# Altium schematic sheet MainBoard.SchDoc(MainBoard)
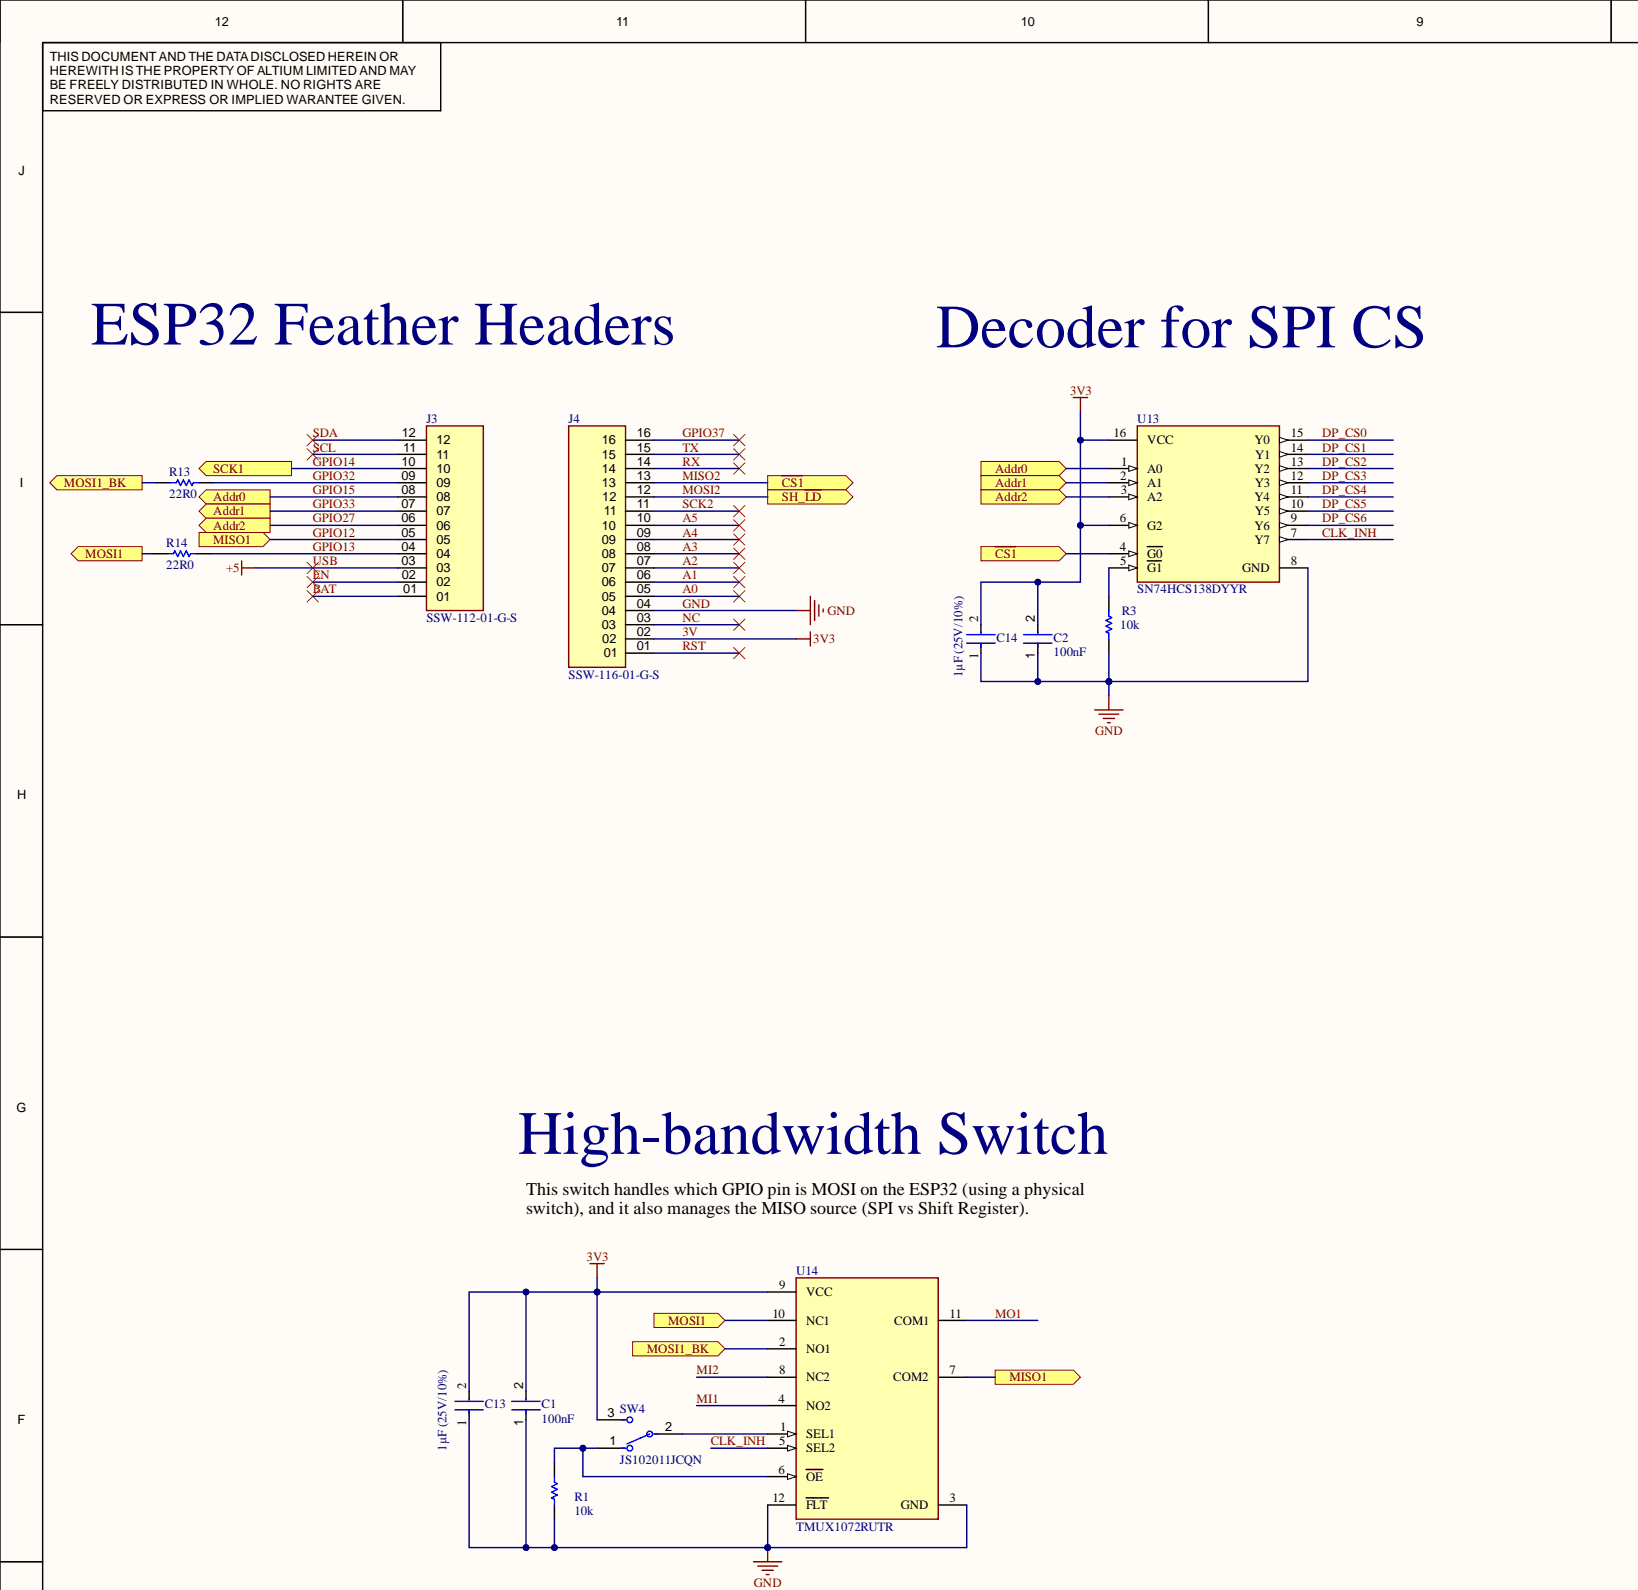
[diagram p0: MainBoard.SchDoc, top left]
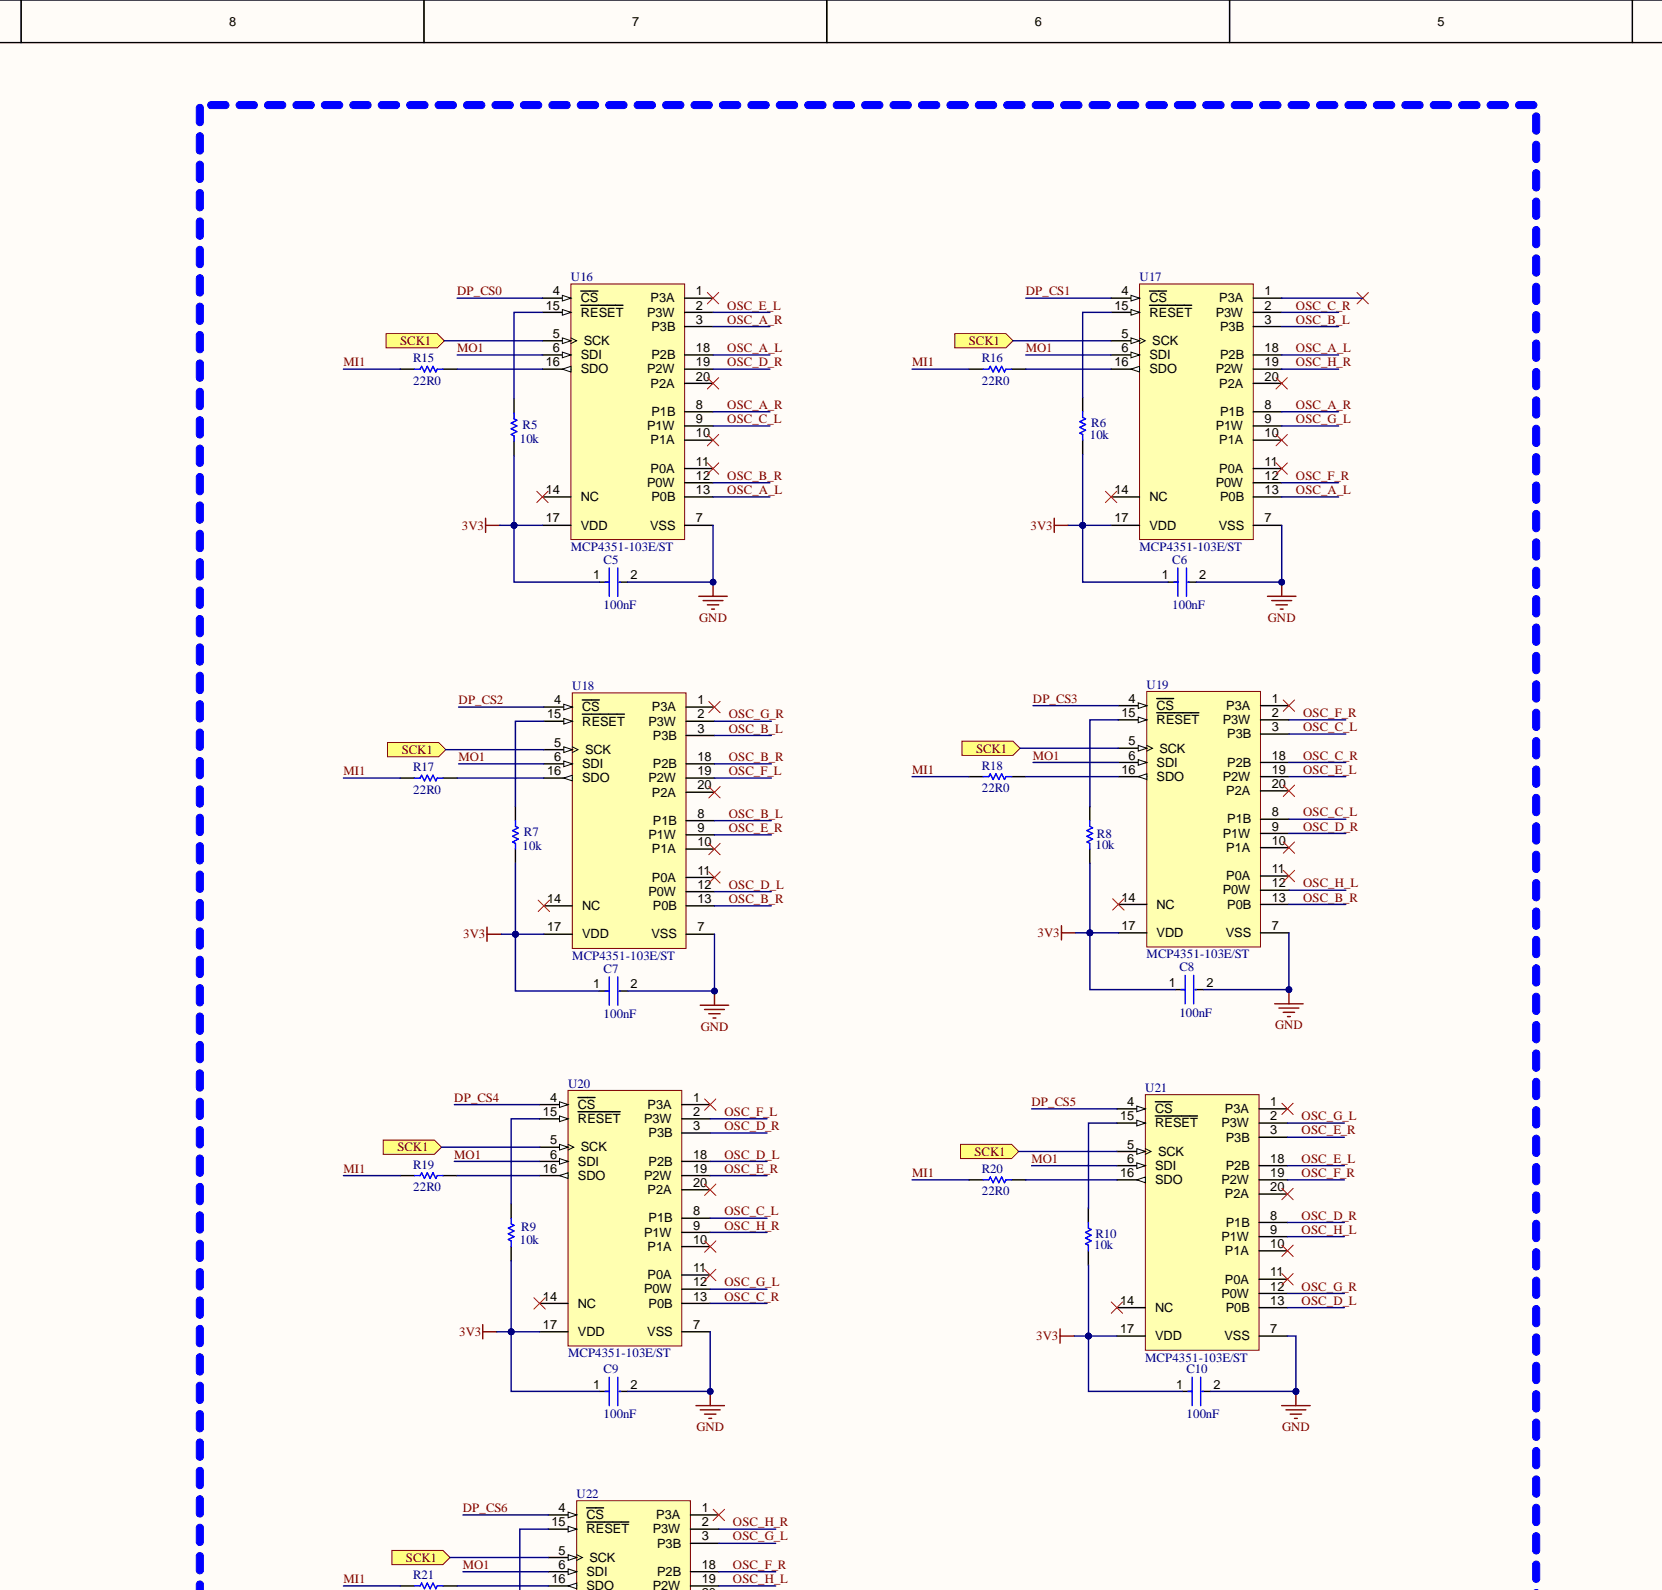
[diagram p1: MainBoard.SchDoc, top centre]
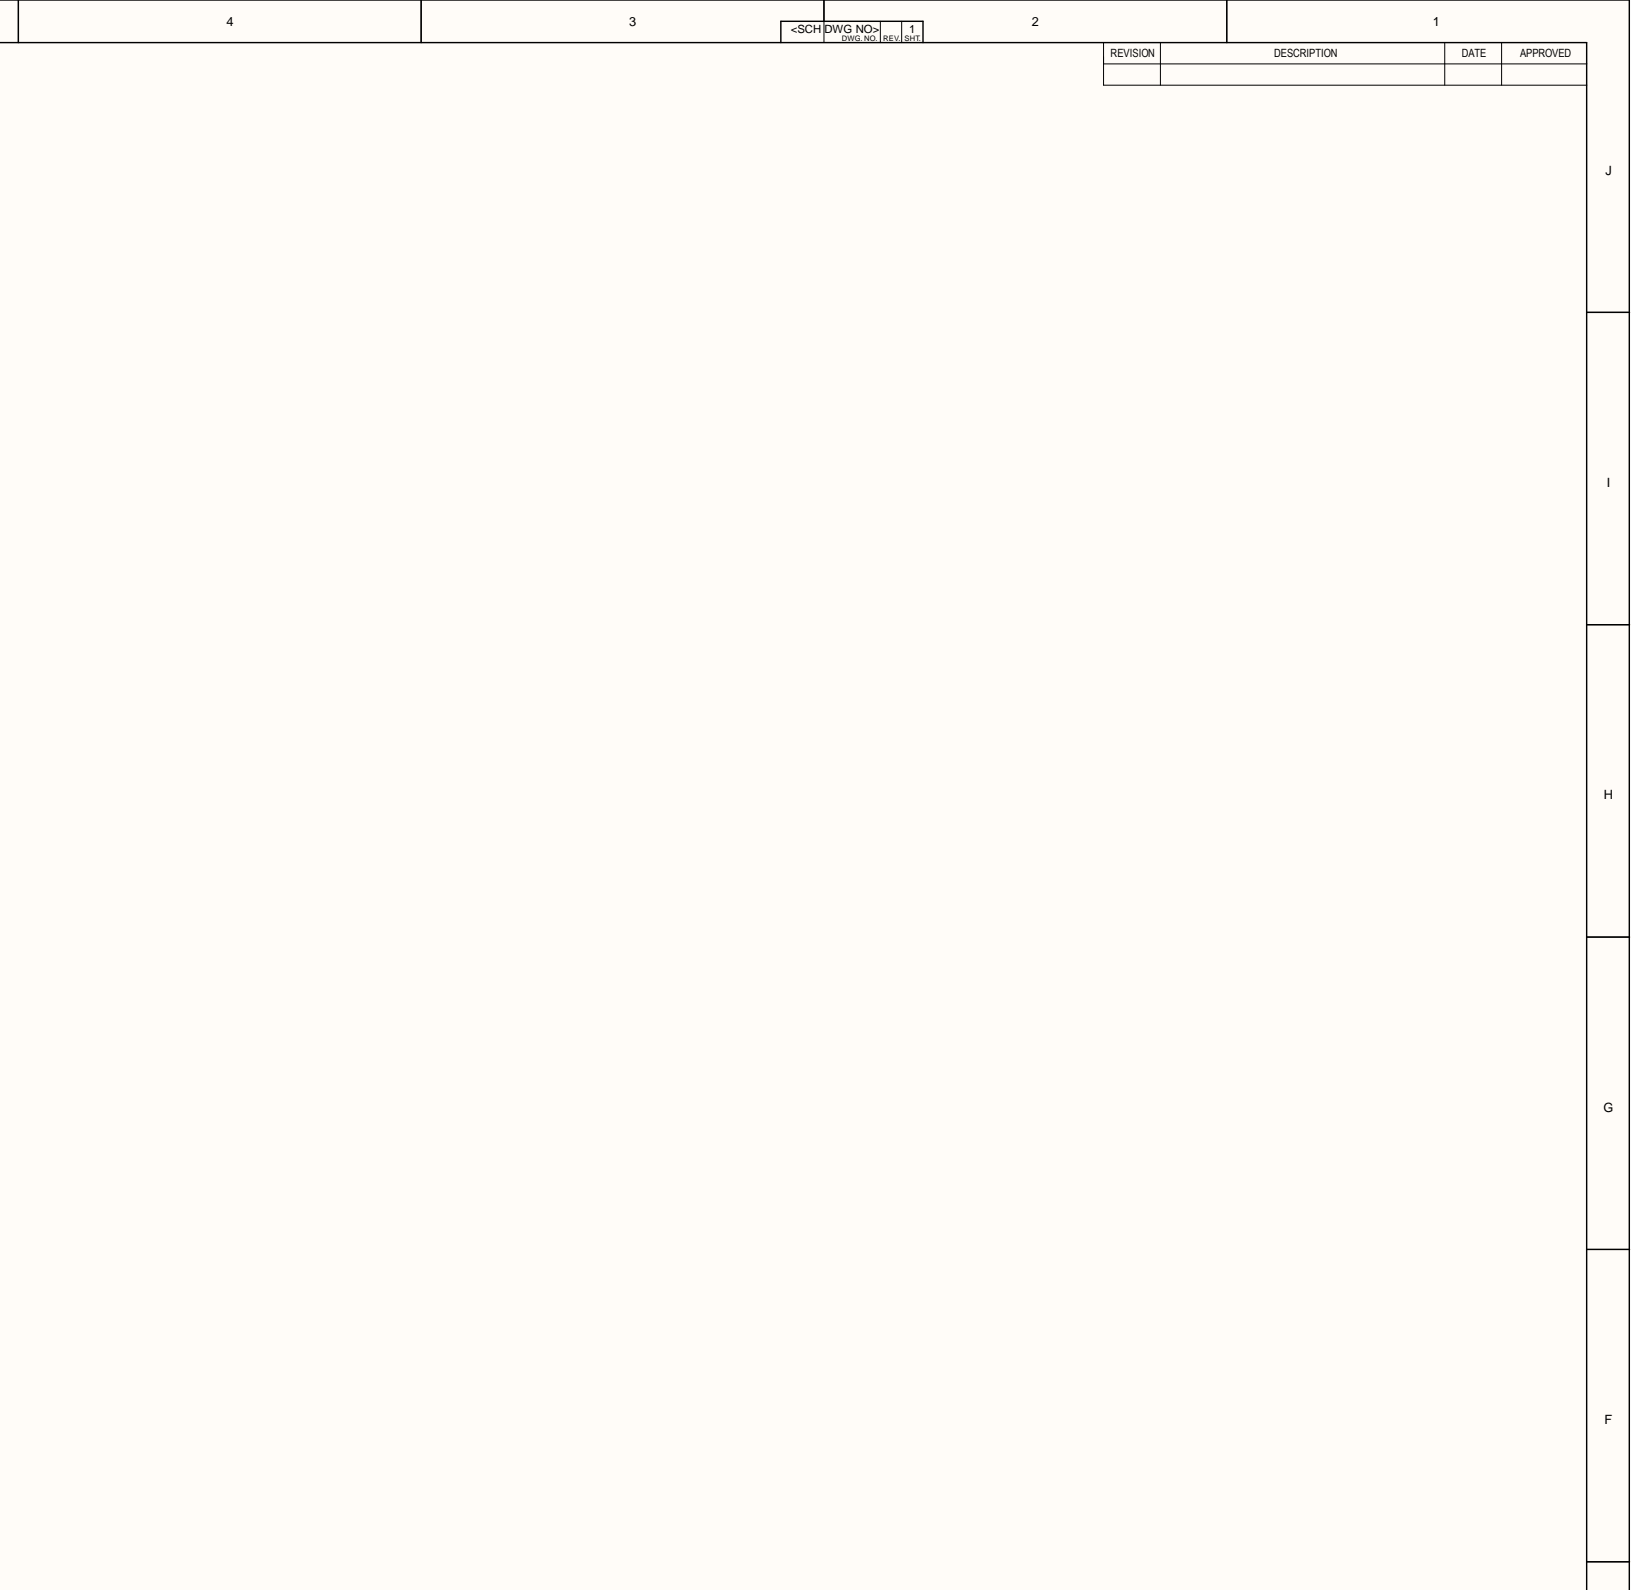
[diagram p2: MainBoard.SchDoc, top right]
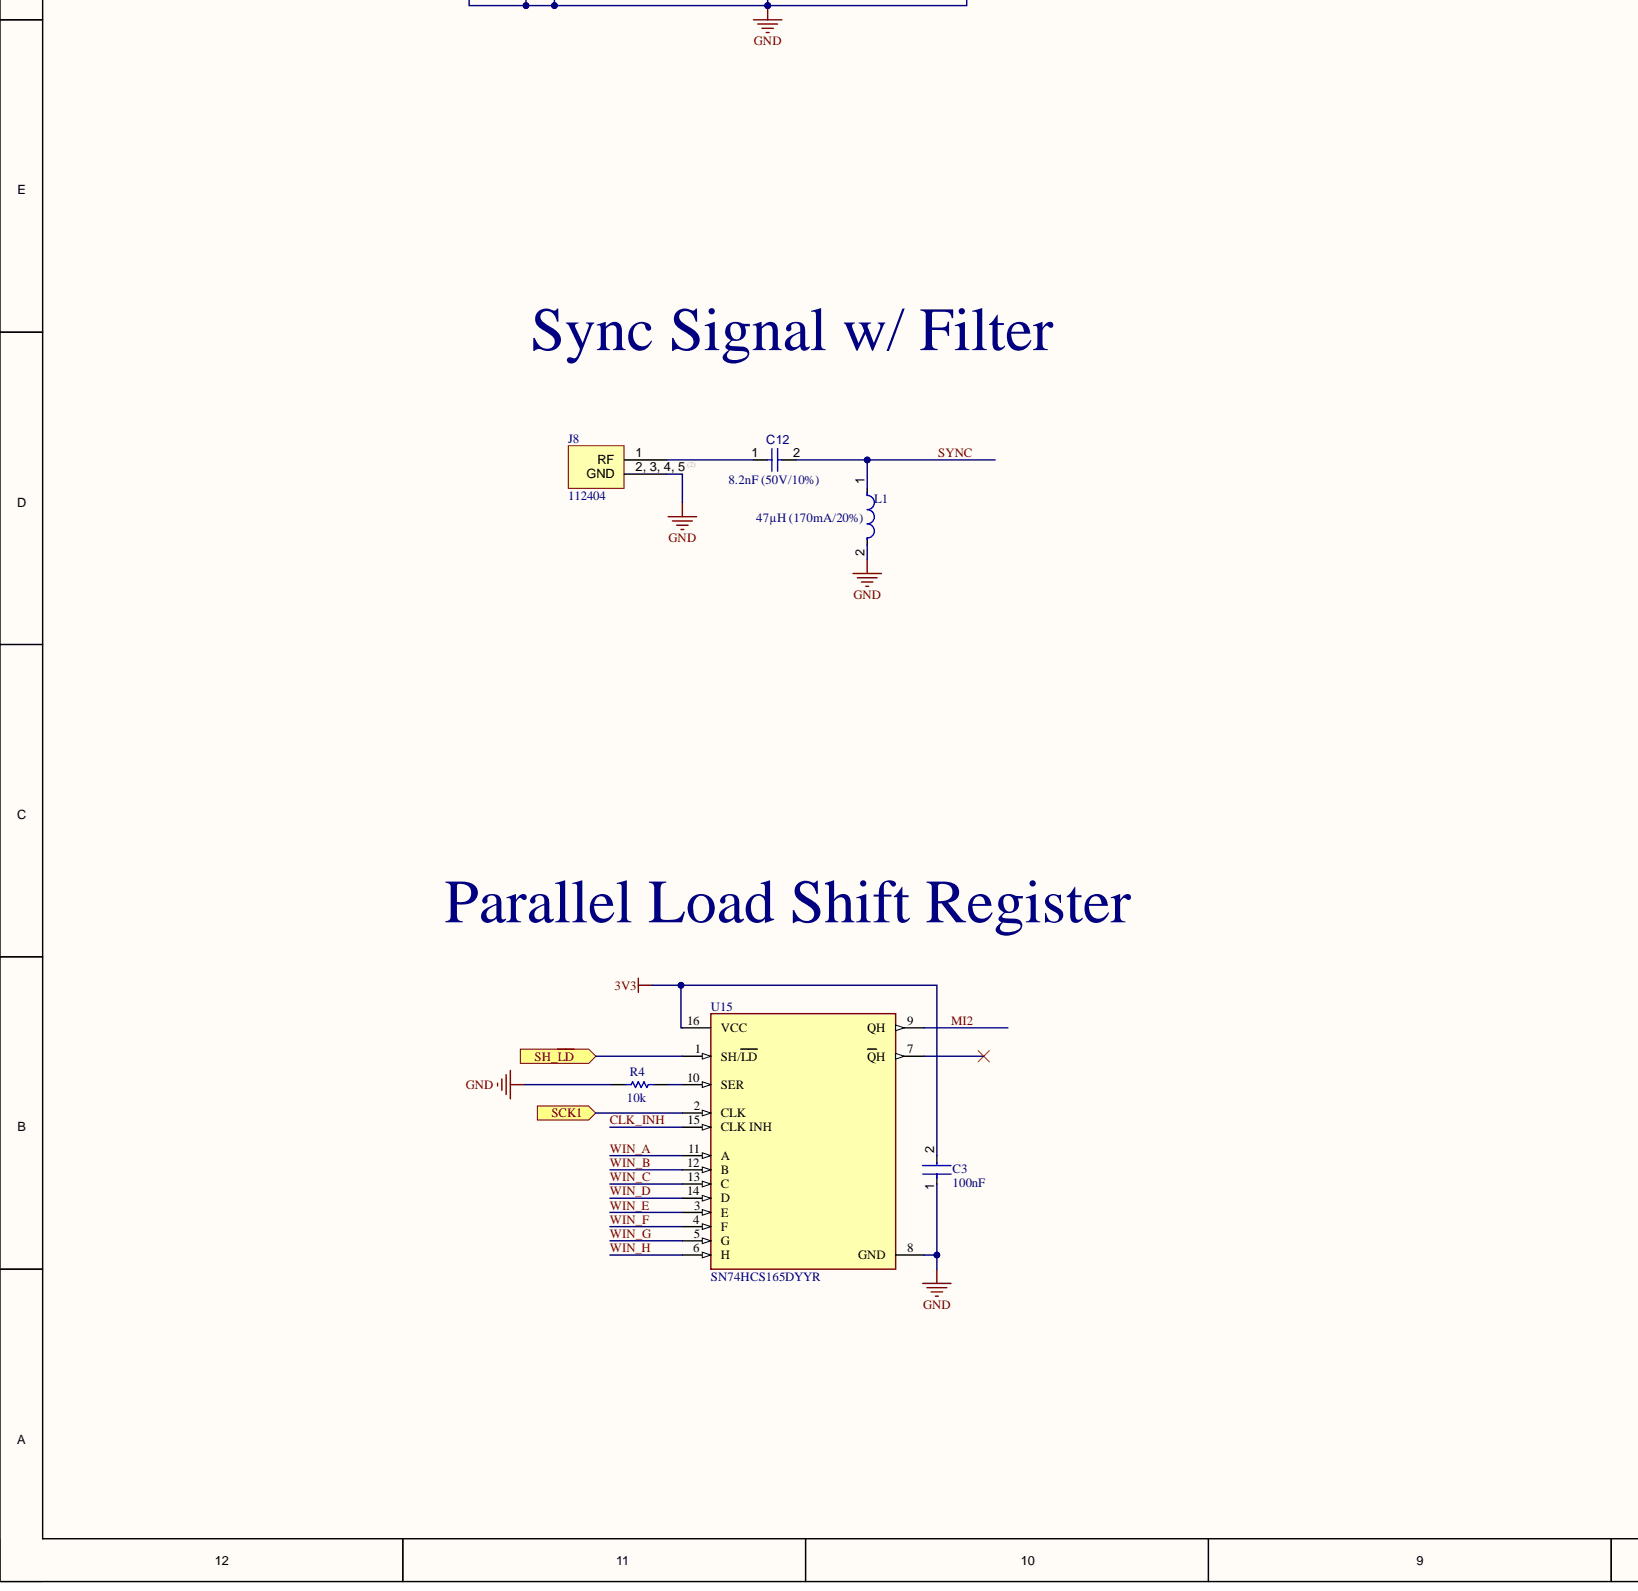
[diagram p3: MainBoard.SchDoc, bottom left]
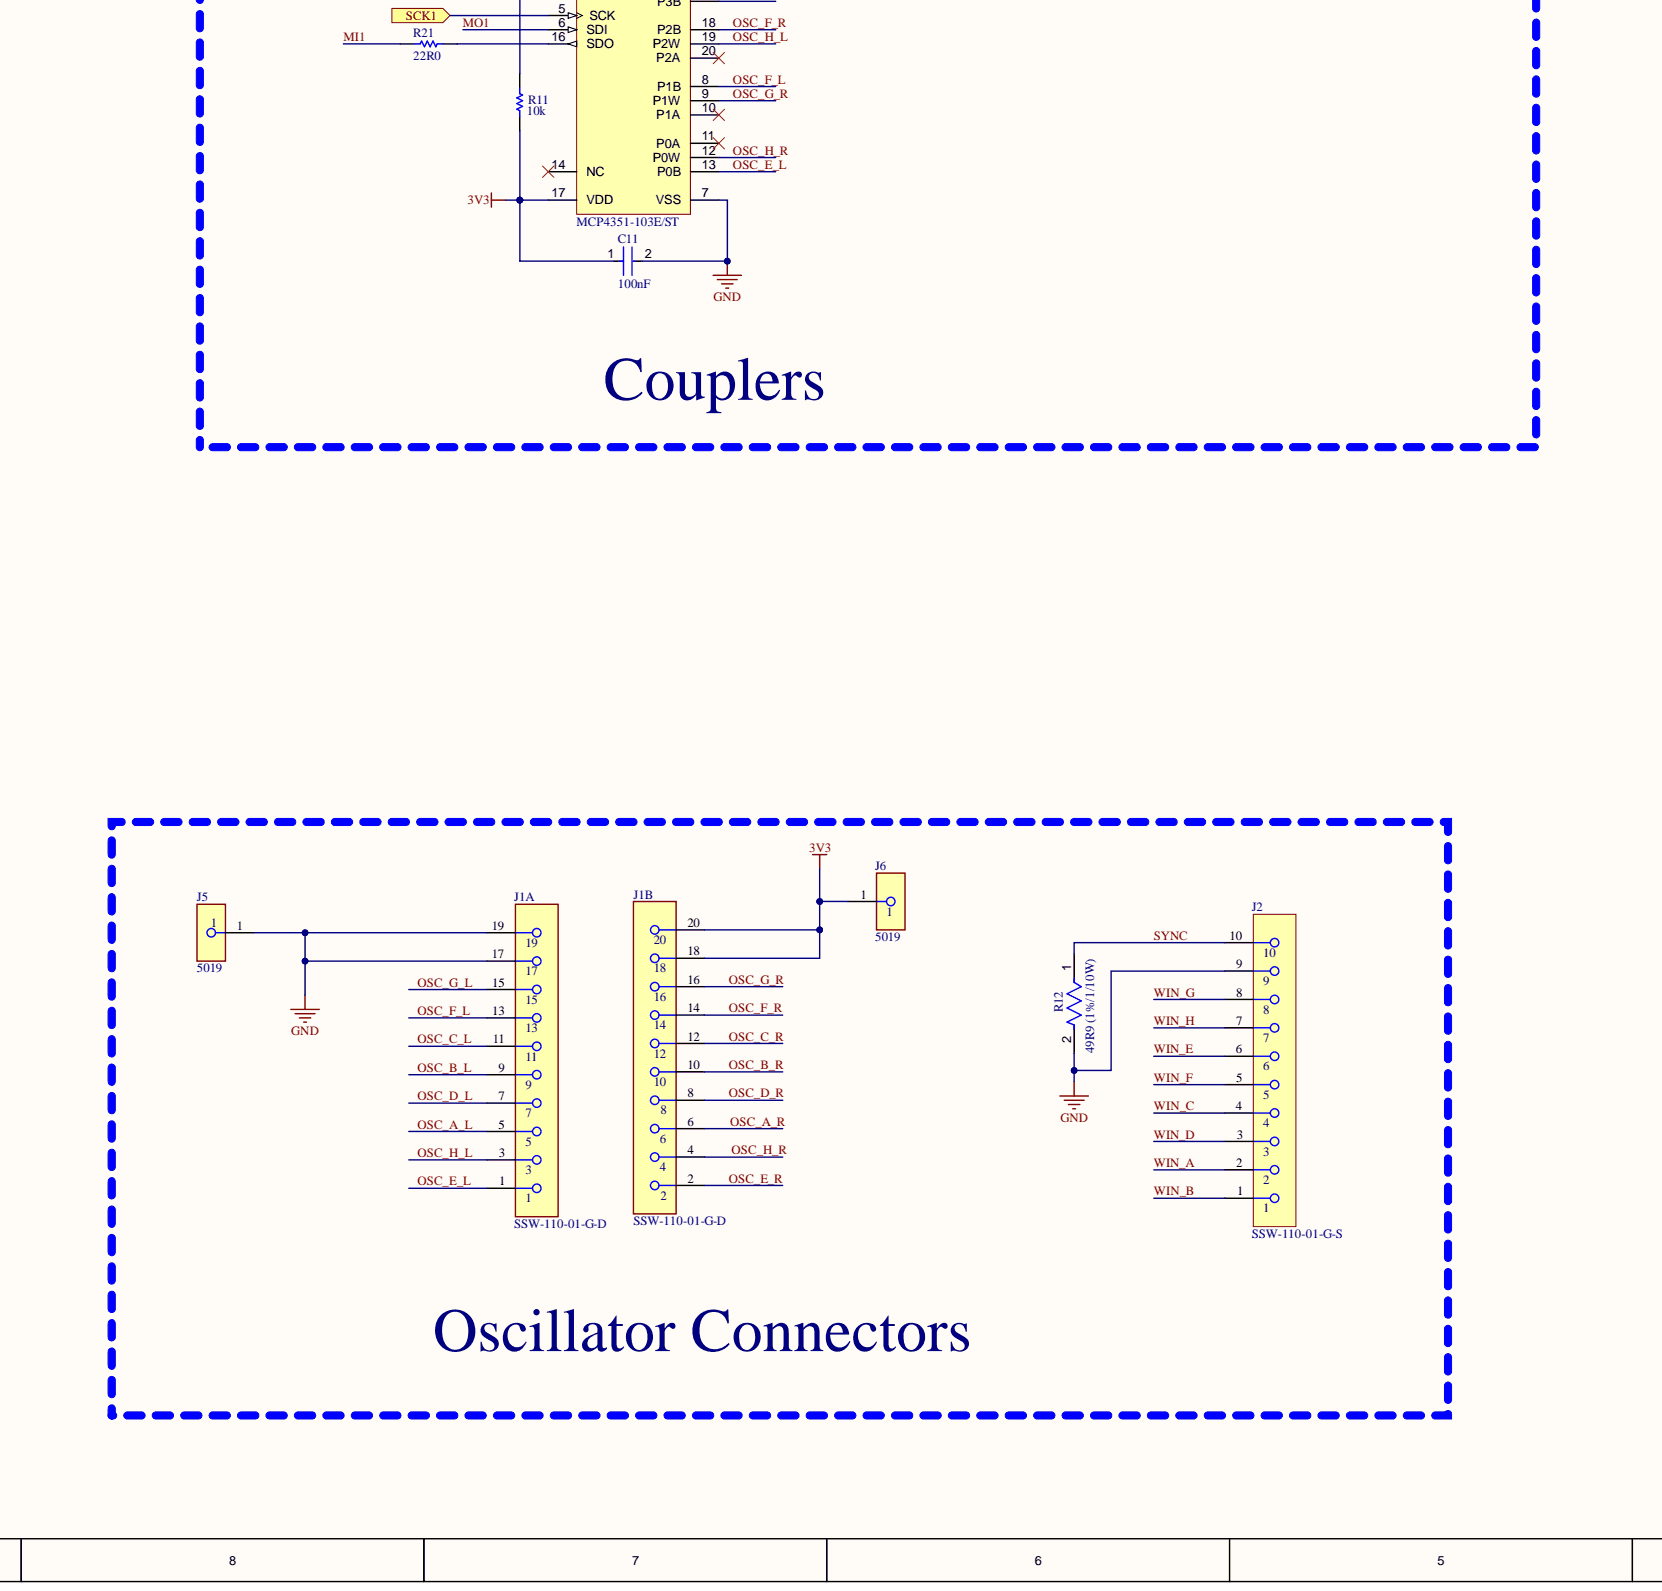
[diagram p4: MainBoard.SchDoc, bottom centre]
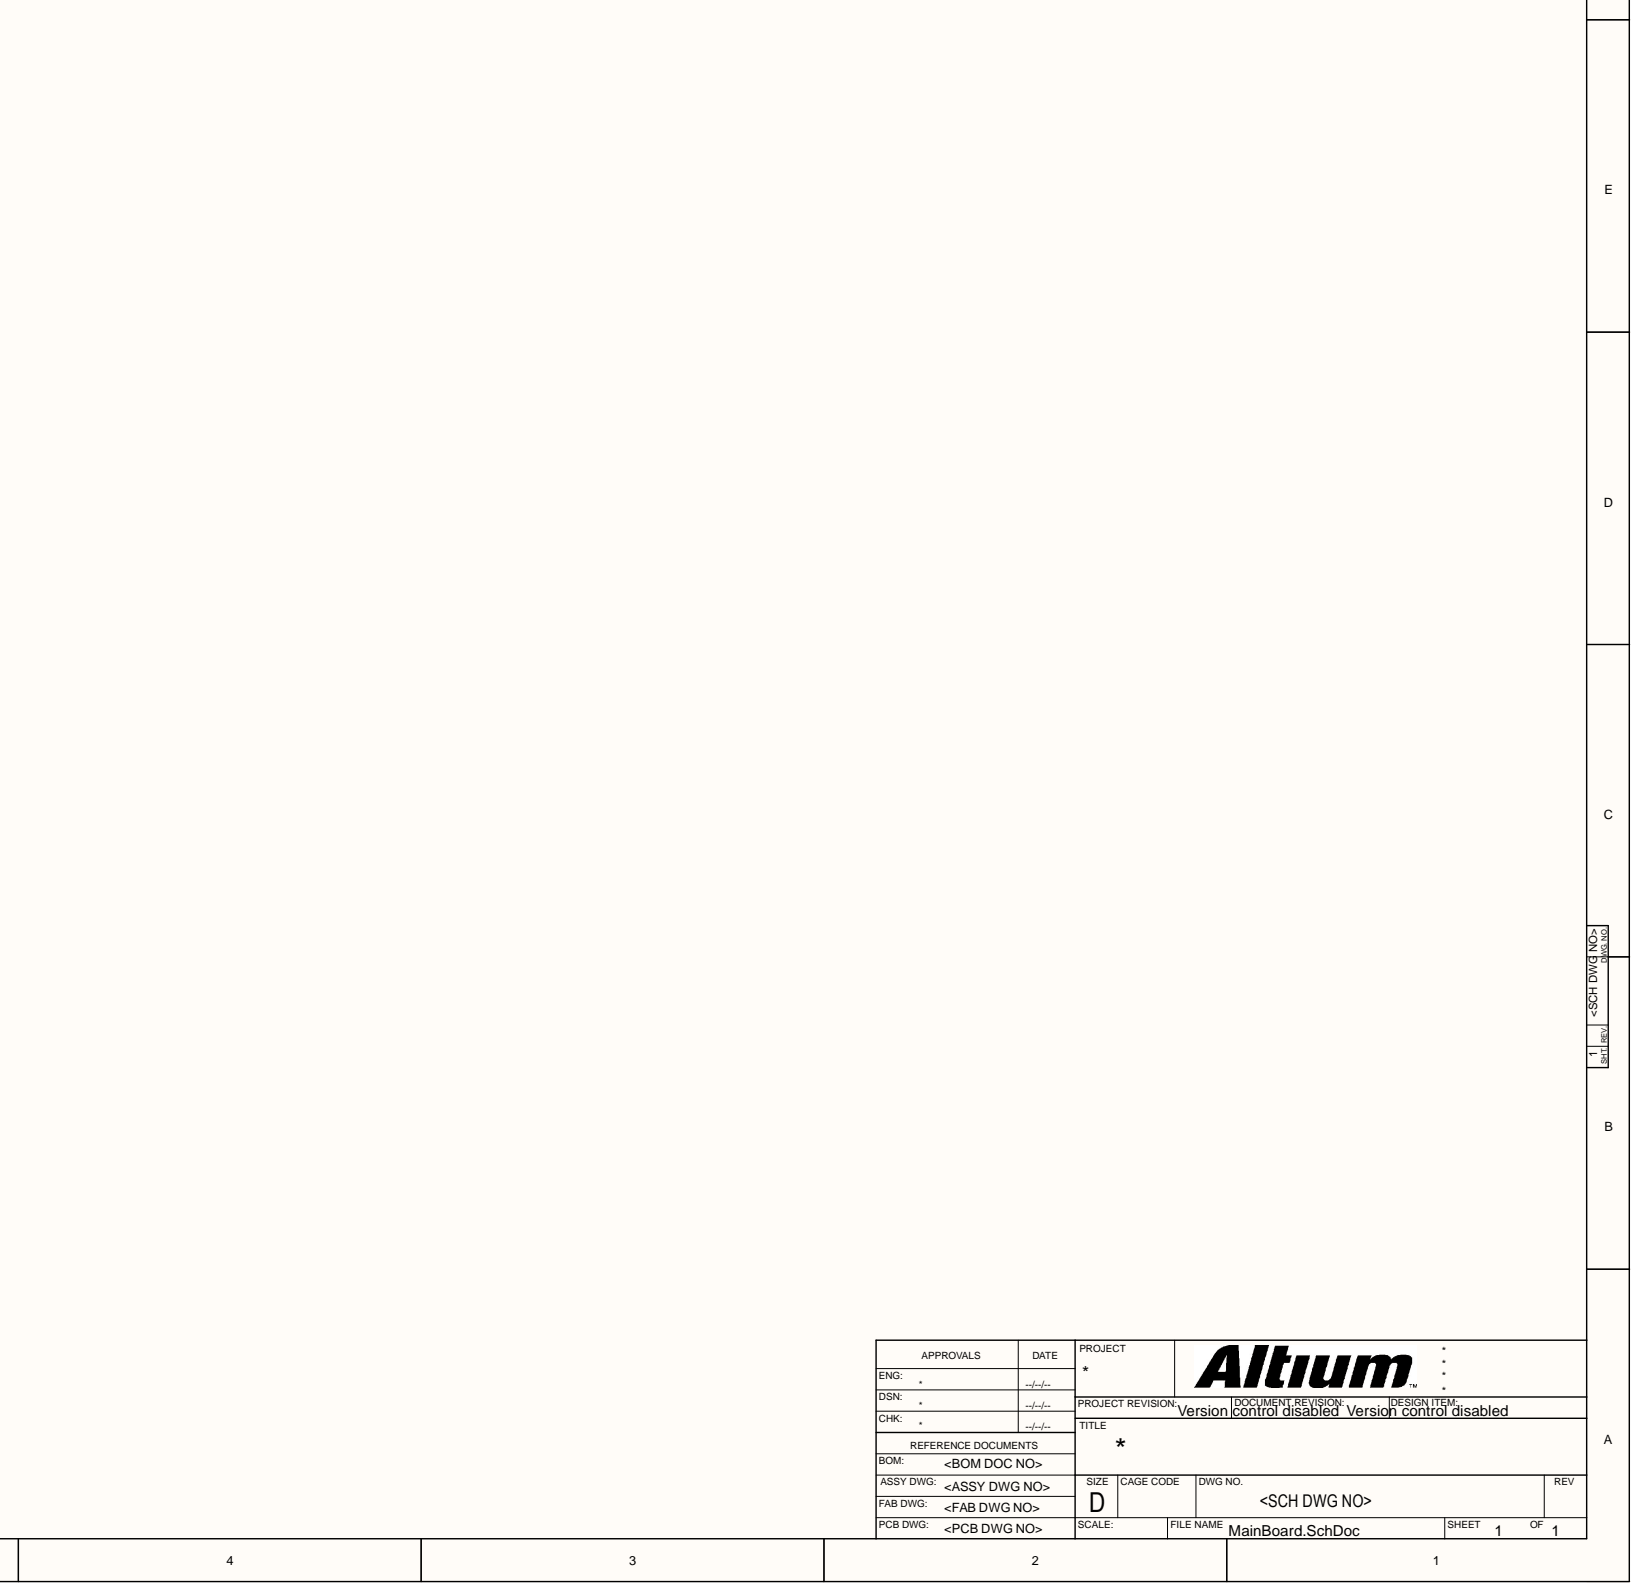
[diagram p5: MainBoard.SchDoc, bottom right]

## Parts
C1  value 100nF  (hidden comment: GRM155C71H104JE19D)
C2  value 100nF  (hidden comment: GRM155C71H104JE19D)
C3  value 100nF  (hidden comment: GRM155C71H104JE19D)
C5  value 100nF  (hidden comment: GRM155C71H104JE19D)
C6  value 100nF  (hidden comment: GRM155C71H104JE19D)
C7  value 100nF  (hidden comment: GRM155C71H104JE19D)
C8  value 100nF  (hidden comment: GRM155C71H104JE19D)
C9  value 100nF  (hidden comment: GRM155C71H104JE19D)
C10  value 100nF  (hidden comment: GRM155C71H104JE19D)
C11  value 100nF  (hidden comment: GRM155C71H104JE19D)
C12  value 8.2nF (50V/10%)  (hidden comment: CC0402JRX7R9BB822)
C13  value 1µF (25V/10%)  (hidden comment: GCM188R71E105KA64D)
C14  value 1µF (25V/10%)  (hidden comment: GCM188R71E105KA64D)
J1  SSW-110-01-G-D  (parts A,B)
J2  SSW-110-01-G-S
J3  SSW-112-01-G-S
J4  SSW-116-01-G-S
J5  5019
J6  5019
J8  112404
L1  value 47µH (170mA/20%)  (hidden comment: MLZ2012M470WTD25)
R1  value 10k  (hidden comment: ERJ-2RHD1002X)
R3  value 10k  (hidden comment: ERJ-2RHD1002X)
R4  value 10k  (hidden comment: ERJ-2RHD1002X)
R5  value 10k  (hidden comment: ERJ-2RHD1002X)
R6  value 10k  (hidden comment: ERJ-2RHD1002X)
R7  value 10k  (hidden comment: ERJ-2RHD1002X)
R8  value 10k  (hidden comment: ERJ-2RHD1002X)
R9  value 10k  (hidden comment: ERJ-2RHD1002X)
R10  value 10k  (hidden comment: ERJ-2RHD1002X)
R11  value 10k  (hidden comment: ERJ-2RHD1002X)
R12  value 49R9 (1%/1/10W)  (hidden comment: ERJ-2RKF49R9X)
R13  value 22R0  (hidden comment: ERJ-2RKF22R0X)
R14  value 22R0  (hidden comment: ERJ-2RKF22R0X)
R15  value 22R0  (hidden comment: ERJ-2RKF22R0X)
R16  value 22R0  (hidden comment: ERJ-2RKF22R0X)
R17  value 22R0  (hidden comment: ERJ-2RKF22R0X)
R18  value 22R0  (hidden comment: ERJ-2RKF22R0X)
R19  value 22R0  (hidden comment: ERJ-2RKF22R0X)
R20  value 22R0  (hidden comment: ERJ-2RKF22R0X)
R21  value 22R0  (hidden comment: ERJ-2RKF22R0X)
SW4  JS102011JCQN
U13  SN74HCS138DYYR
U14  TMUX1072RUTR
U15  SN74HCS165DYYR
U16  MCP4351-103E/ST
U17  MCP4351-103E/ST
U18  MCP4351-103E/ST
U19  MCP4351-103E/ST
U20  MCP4351-103E/ST
U21  MCP4351-103E/ST
U22  MCP4351-103E/ST

## Ports
Addr0, Addr1, Addr2, MISO1, MOSI1, MOSI1_BK, SCK1, SH_~{LD}, ~{CS1}

## Nets
3V: C1.2 C2.2 C3.2 C5.1 C6.1 C7.1 C8.1 C9.1 C10.1 C11.1 C13.2 C14.2 J1.18 J1.20 J4.02 J6.1 R5.2 R6.2 R7.2 R8.2 R9.2 R10.2 R11.2 SW4.3 U13.6(G2) U13.16(VCC) U14.9(VCC) U15.16 U16.17(VDD) U17.17(VDD) U18.17(VDD) U19.17(VDD) U20.17(VDD) U21.17(VDD) U22.17(VDD)
A0: J4.05
A1: J4.06
A2: J4.07
A3: J4.08
A4: J4.09
A5: J4.10
BAT: J3.01
CLK_INH: U13.7(Y7) U14.5(SEL2) U15.15
DP_CS0: U13.15(Y0) U16.4(CS)
DP_CS1: U13.14(Y1) U17.4(CS)
DP_CS2: U13.13(Y2) U18.4(CS)
DP_CS3: U13.12(Y3) U19.4(CS)
DP_CS4: U13.11(Y4) U20.4(CS)
DP_CS5: U13.10(Y5) U21.4(CS)
DP_CS6: U13.9(Y6) U22.4(CS)
EN: J3.02
GND: C1.1 C2.1 C3.1 C5.2 C6.2 C7.2 C8.2 C9.2 C10.2 C11.2 C13.1 C14.1 J1.17 J1.19 J2.9 J4.04 J5.1 J8.2 L1.2 R1.2 R3.1 R4.2 R12.2 U13.8(GND) U14.3(GND) U14.12(FLT) U15.8 U16.7(VSS) U17.7(VSS) U18.7(VSS) U19.7(VSS) U20.7(VSS) U21.7(VSS) U22.7(VSS)
GPIO12: J3.05 U14.7(COM2) ; port MISO1
GPIO13: J3.04 R14.2
GPIO14: J3.10 U15.2 U16.5(SCK) U17.5(SCK) U18.5(SCK) U19.5(SCK) U20.5(SCK) U21.5(SCK) U22.5(SCK) ; port SCK1
GPIO15: J3.08 U13.1(A0) ; port Addr0
GPIO27: J3.06 U13.3(A2) ; port Addr2
GPIO32: J3.09 R13.2
GPIO33: J3.07 U13.2(A1) ; port Addr1
GPIO37: J4.16
MI1: R15.1 R16.1 R17.1 R18.1 R19.1 R20.1 R21.1 U14.4(NO2)
MI2: U14.8(NC2) U15.9
MISO2: J4.13 U13.4(G0) ; port ~{CS1}
MO1: U14.11(COM1) U16.6(SDI) U17.6(SDI) U18.6(SDI) U19.6(SDI) U20.6(SDI) U21.6(SDI) U22.6(SDI)
MOSI1: R14.1 U14.10(NC1) ; port MOSI1
MOSI1_BK: R13.1 U14.2(NO1) ; port MOSI1_BK
MOSI2: J4.12 U15.1 ; port SH_~{LD}
NC: J4.03
OSC_A_L: J1.5 U16.13(P0B) U16.18(P2B) U17.13(P0B) U17.18(P2B)
OSC_A_R: J1.6 U16.3(P3B) U16.8(P1B) U17.8(P1B)
OSC_B_L: J1.9 U17.3(P3B) U18.3(P3B) U18.8(P1B)
OSC_B_R: J1.10 U16.12(P0W) U18.13(P0B) U18.18(P2B) U19.13(P0B)
OSC_C_L: J1.11 U16.9(P1W) U19.3(P3B) U19.8(P1B) U20.8(P1B)
OSC_C_R: J1.12 U17.2(P3W) U19.18(P2B) U20.13(P0B)
OSC_D_L: J1.7 U18.12(P0W) U20.18(P2B) U21.13(P0B)
OSC_D_R: J1.8 U16.19(P2W) U19.9(P1W) U20.3(P3B) U21.8(P1B)
OSC_E_L: J1.1 U16.2(P3W) U19.19(P2W) U21.18(P2B) U22.13(P0B)
OSC_E_R: J1.2 U18.9(P1W) U20.19(P2W) U21.3(P3B)
OSC_F_L: J1.13 U18.19(P2W) U20.2(P3W) U22.8(P1B)
OSC_F_R: J1.14 U17.12(P0W) U19.2(P3W) U21.19(P2W) U22.18(P2B)
OSC_G_L: J1.15 U17.9(P1W) U20.12(P0W) U21.2(P3W) U22.3(P3B)
OSC_G_R: J1.16 U18.2(P3W) U21.12(P0W) U22.9(P1W)
OSC_H_L: J1.3 U19.12(P0W) U21.9(P1W) U22.19(P2W)
OSC_H_R: J1.4 U17.19(P2W) U20.9(P1W) U22.2(P3W) U22.12(P0W)
RST: J4.01
RX: J4.14
SCK2: J4.11
SCL: J3.11
SDA: J3.12
SYNC: C12.2 J2.10 L1.1 R12.1
TX: J4.15
USB: J3.03
WIN_A: J2.2 U15.11
WIN_B: J2.1 U15.12
WIN_C: J2.4 U15.13
WIN_D: J2.3 U15.14
WIN_E: J2.6 U15.3
WIN_F: J2.5 U15.4
WIN_G: J2.8 U15.5
WIN_H: J2.7 U15.6
NetC12_1: C12.1 J8.1
NetR1_1: R1.1 SW4.1 U14.6(OE)
NetR3_2: R3.2 U13.5(G1)
NetR4_1: R4.1 U15.10
NetR5_1: R5.1 U16.15(RESET)
NetR6_1: R6.1 U17.15(RESET)
NetR7_1: R7.1 U18.15(RESET)
NetR8_1: R8.1 U19.15(RESET)
NetR9_1: R9.1 U20.15(RESET)
NetR10_1: R10.1 U21.15(RESET)
NetR11_1: R11.1 U22.15(RESET)
NetR15_2: R15.2 U16.16(SDO)
NetR16_2: R16.2 U17.16(SDO)
NetR17_2: R17.2 U18.16(SDO)
NetR18_2: R18.2 U19.16(SDO)
NetR19_2: R19.2 U20.16(SDO)
NetR20_2: R20.2 U21.16(SDO)
NetR21_2: R21.2 U22.16(SDO)
NetSW4_2: SW4.2 U14.1(SEL1)

Not connected: U15 7; U16 1(P3A) 10(P1A) 11(P0A) 14(NC) 20(P2A); U17 1(P3A) 10(P1A) 11(P0A) 14(NC) 20(P2A); U18 1(P3A) 10(P1A) 11(P0A) 14(NC) 20(P2A); U19 1(P3A) 10(P1A) 11(P0A) 14(NC) 20(P2A); U20 1(P3A) 10(P1A) 11(P0A) 14(NC) 20(P2A); U21 1(P3A) 10(P1A) 11(P0A) 14(NC) 20(P2A); U22 1(P3A) 10(P1A) 11(P0A) 14(NC) 20(P2A)

## Library data of the parts
C1-C3 C5-C11 = CMP-001-00090-1: Ceramic Capacitor, Multilayer, Ceramic, 50V, 5% +Tol, 5% -Tol, X7S, 22% TC, 0.1uF, Surface Mount, 0402; Capacitor Type: Ceramic; Case/Package: 0402; Component Kind: Standard; Max Operating Temperature: 125°C; Min Operating Temperature: -55°C; Mounting Technology: Surface Mount; Pins: 2; RoHS Compliant: Yes; Library Name: Ben Anderson
C12 = CMP-001-00088-1: Ceramic Capacitor, Multilayer, Ceramic, 50V, 5% +Tol, 5% -Tol, X7R, 15% TC, 0.0082uF, Surface Mount, 0402; Capacitor Type: Ceramic; Case/Package: 0402; Component Kind: Standard; Max Operating Temperature: 125°C; Min Operating Temperature: -55°C; Mounting Technology: Surface Mount; Pins: 2; RoHS Compliant: Yes; Library Name: Ben Anderson
C13 C14 = CMP-001-00086-1: Ceramic Capacitor, Multilayer, Ceramic, 25V, 10% +Tol, 10% -Tol, X7R, 15% TC, 1uF, Surface Mount, 0603; Capacitor Type: Ceramic; Case/Package: 0603; Component Kind: Standard; Max Operating Temperature: 125°C; Min Operating Temperature: -55°C; Mounting Technology: Surface Mount; Pins: 2; RoHS Compliant: Yes; Library Name: Ben Anderson
J1 = CMP-02766-000052-2: CONN RCPT 20POS 0.1 GOLD PCB; Connector Type: Receptacle; Contact Resistance: 10mR; Current Rating: 6.9A; Mating Cycles: 100; Max Voltage Rating (AC): 550V; Number of Contacts: 20; Pitch: 2.54mm; Row Spacing: 0.1inch; Color: Black; Component Kind: Standard; Contact Material: Bronze; Contact Plating: Gold; Contact Type: Female Socket; Depth: 4.95mm; Gender: Receptacle; Housing Color: Black; Housing Material: Polymer; Lead Free: Lead Free; Lead Pitch: 2.54mm; Length: 25.91mm; Library Name: Altium Content Vault; Max Current Rating: 6.9A; Max Operating Temperature: 125°C; Min Operating Temperature: -55°C; Mount: Through Hole; Number of Positions: 20; Number of Rows: 2; Orientation: Straight; Packaging: Bulk; Radiation Hardening: No; REACH SVHC: No SVHC; RoHS Compliant: Yes; Series: SSW; Termination: Solder; Voltage Rating: 550V
J2 = CMP-1272-00002-2: Manufacturer Lifecycle Status: PRODUCTION; NexarPartId: 296065; Connector Type: Socket; Contact Resistance: 10mΩ; Current Rating: 6.9A; Gender: Receptacle; Lead Length: 2.64mm; Max Voltage Rating (AC): 550V; Number of Contacts: 10; Pitch: 2.54mm; Voltage Rating (AC): 465V; Voltage Rating (DC): 655V; Color: Black; Component Kind: Standard; Contact Material: Bronze; Contact Plating: Gold; Depth: 2.41mm; Housing Color: Black; Lead Free: Lead Free; Lead Pitch: 2.54mm; Length: 25.91mm; Library Name: Altium Content Vault; Lifecycle Status: Production; Max Current Rating: 6.9A; Max Operating Temperature: 125°C; Min Operating Temperature: -55°C; Number of Positions: 10; Number of Rows: 1; Orientation: Vertical; Packaging: Bulk; Radiation Hardening: No; REACH SVHC: No SVHC; RoHS: Compliant; Termination: Solder; Voltage Rating: 550V
J3 = CMP-005-000000-2: Board Connector, 12 Contact(s), 1 Row(s), Female, Straight, 0.1 inch Pitch, Solder Terminal, Locking, Socket; MF: {'manufacturer': u'Samtec Inc.'}; MP: SSW-112-01-X-S; Availability: Unavailable; Component Kind: Standard; Component Type: Standard; Connector Type: BOARD CONNECTOR; Design Item ID: SSW-112-01-X-S; Mounting Technology: Board; Package: None; Pin Count: 12; Price: None; RoHS Compliant: Yes; Source: SSW-112-01-G-S.SchLib; Library Name: Ben Anderson
J4 = CMP-005-000001-2: Board Connector, 16 Contact(s), 1 Row(s), Female, Straight, 0.1 inch Pitch, Solder Terminal, Locking, Socket; MF: {'manufacturer': u'Samtec'}; MP: SSW-116-XX-X-S; Availability: Unavailable; Component Kind: Standard; Component Type: Standard; Connector Type: BOARD CONNECTOR; Design Item ID: SSW-116-XX-X-S; Mounting Technology: Board; Package: None; Pin Count: 16; Price: None; RoHS Compliant: Yes; Source: SSW-116-01-G-S.SchLib; Library Name: Ben Anderson
J5 J6 = CMP-00030-00001-2: Interconnection Device; Component Kind: Standard; RoHS Compliant: Yes; Library Name: Ben Anderson
J8 = CMP-002-00078-1: RF BNC Connector, Female, Board Mount, Solder Terminal, Jack; Component Kind: Standard; Connector Type: BNC; Mounting Technology: Board; RoHS Compliant: Yes; Library Name: Ben Anderson
L1 = CMP-006-00026-1: General Purpose Inductor, 47uH, 20%, 1 Element, Ferrite-Core, SMD, 0805; Value: 47µH (170mA/20%); Component Kind: Standard; RoHS Compliant: Yes; Library Name: Ben Anderson
R1 R3-R11 = CMP-009-00178-1: Fixed Resistor, Metal Glaze/thick Film, 0.063W, 10000ohm, 50V, 0.5% +/-Tol, 50ppm/Cel, Surface Mount, 0402; Power: 63mW; Case/Package: 0402; Component Kind: Standard; Max Operating Temperature: 125°C; Min Operating Temperature: -55°C; Mounting Technology: Surface Mount; Pins: 2; RoHS Compliant: Yes; Tolerance: 0.5%; Library Name: Ben Anderson
R12 = CMP-009-00179-1: Fixed Resistor, Metal Glaze/thick Film, 0.1W, 49.9ohm, 50V, 1% +/-Tol, 100ppm/Cel, Surface Mount, 0402; Power: 100mW; Case/Package: 0402; Component Kind: Standard; Max Operating Temperature: 155°C; Min Operating Temperature: -55°C; Mounting Technology: Surface Mount; Pins: 2; RoHS Compliant: Yes; Tolerance: 1%; Library Name: Ben Anderson
R13-R21 = CMP-009-00180-1: Fixed Resistor, Metal Glaze/thick Film, 0.1W, 22ohm, 50V, 1% +/-Tol, 100ppm/Cel, Surface Mount, 0402; Power: 100mW; Case/Package: 0402; Component Kind: Standard; Max Operating Temperature: 155°C; Min Operating Temperature: -55°C; Mounting Technology: Surface Mount; Pins: 2; RoHS Compliant: Yes; Tolerance: 1%; Library Name: Ben Anderson
SW4 = CMP-011-00014-1: Slide Switch, SPDT, Latched, 0.3A, 6VDC, Solder Terminal, Surface Mount-straight; Component Kind: Standard; Library Name: Ben Anderson
U13 = CMP-016-00016-1: 3-line to 8-line decoders/demultiplexer with Schmitt-trigger inputs 16-SOT-23-THIN -40 to 125; Component Kind: Standard; Library Name: Ben Anderson
U14 = CMP-016-00020-1: Single-Ended Multiplexer, 1 Func, 1 Channel, PQCC12; Component Kind: Standard; RoHS Compliant: Yes; Library Name: Ben Anderson
U15 = CMP-016-00018-1: Parallel In Serial Out, HC Series, 8-Bit, Right Direction, Complementary Output, CMOS, PDSO16; Component Kind: Standard; Library Name: Ben Anderson
U16-U22 = CMP-031-00006-1: Digital Potentiometer, 4 Func, 10000ohm, 3-wire Serial Control Interface, 257 Positions, CMOS, PDSO20; Case/Package: TSSOP; Component Kind: Standard; Max Operating Temperature: 125°C; Min Operating Temperature: -40°C; Pins: 20; RoHS Compliant: Yes; Library Name: Ben Anderson
